# Altium schematic sheet DAC.SchDoc
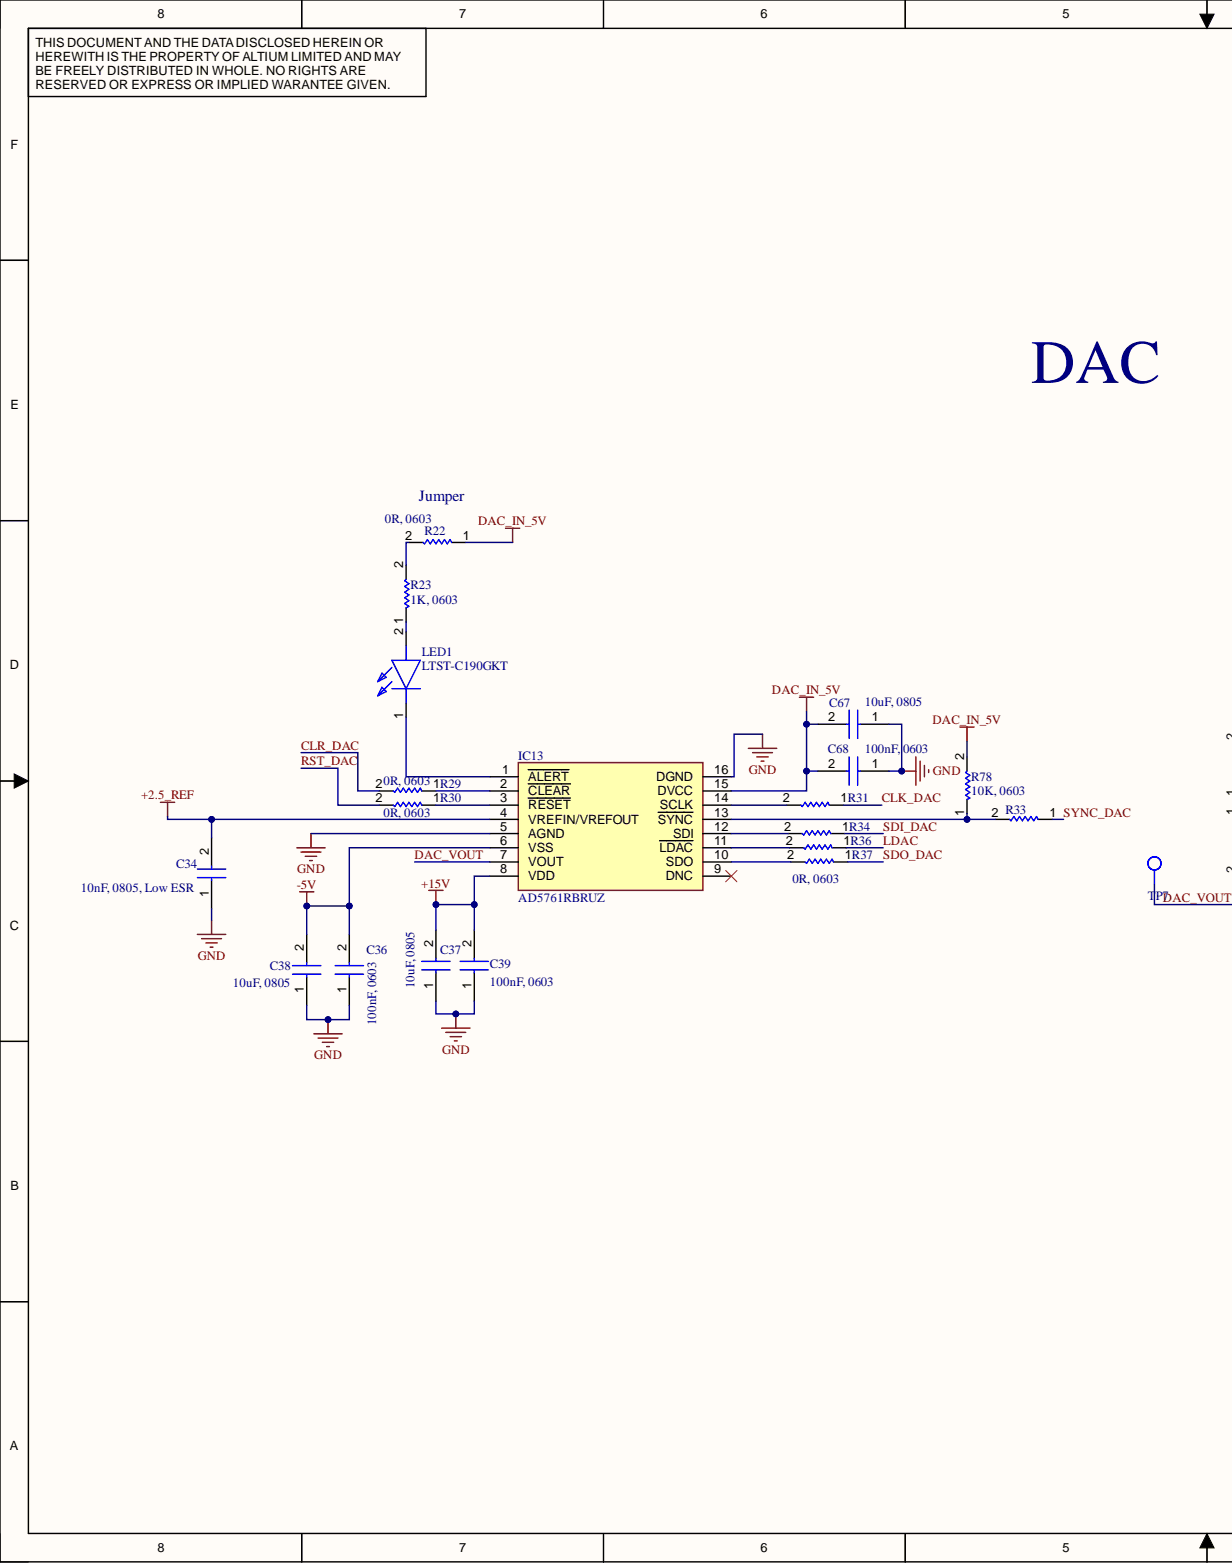
[diagram p0: DAC.SchDoc, left]
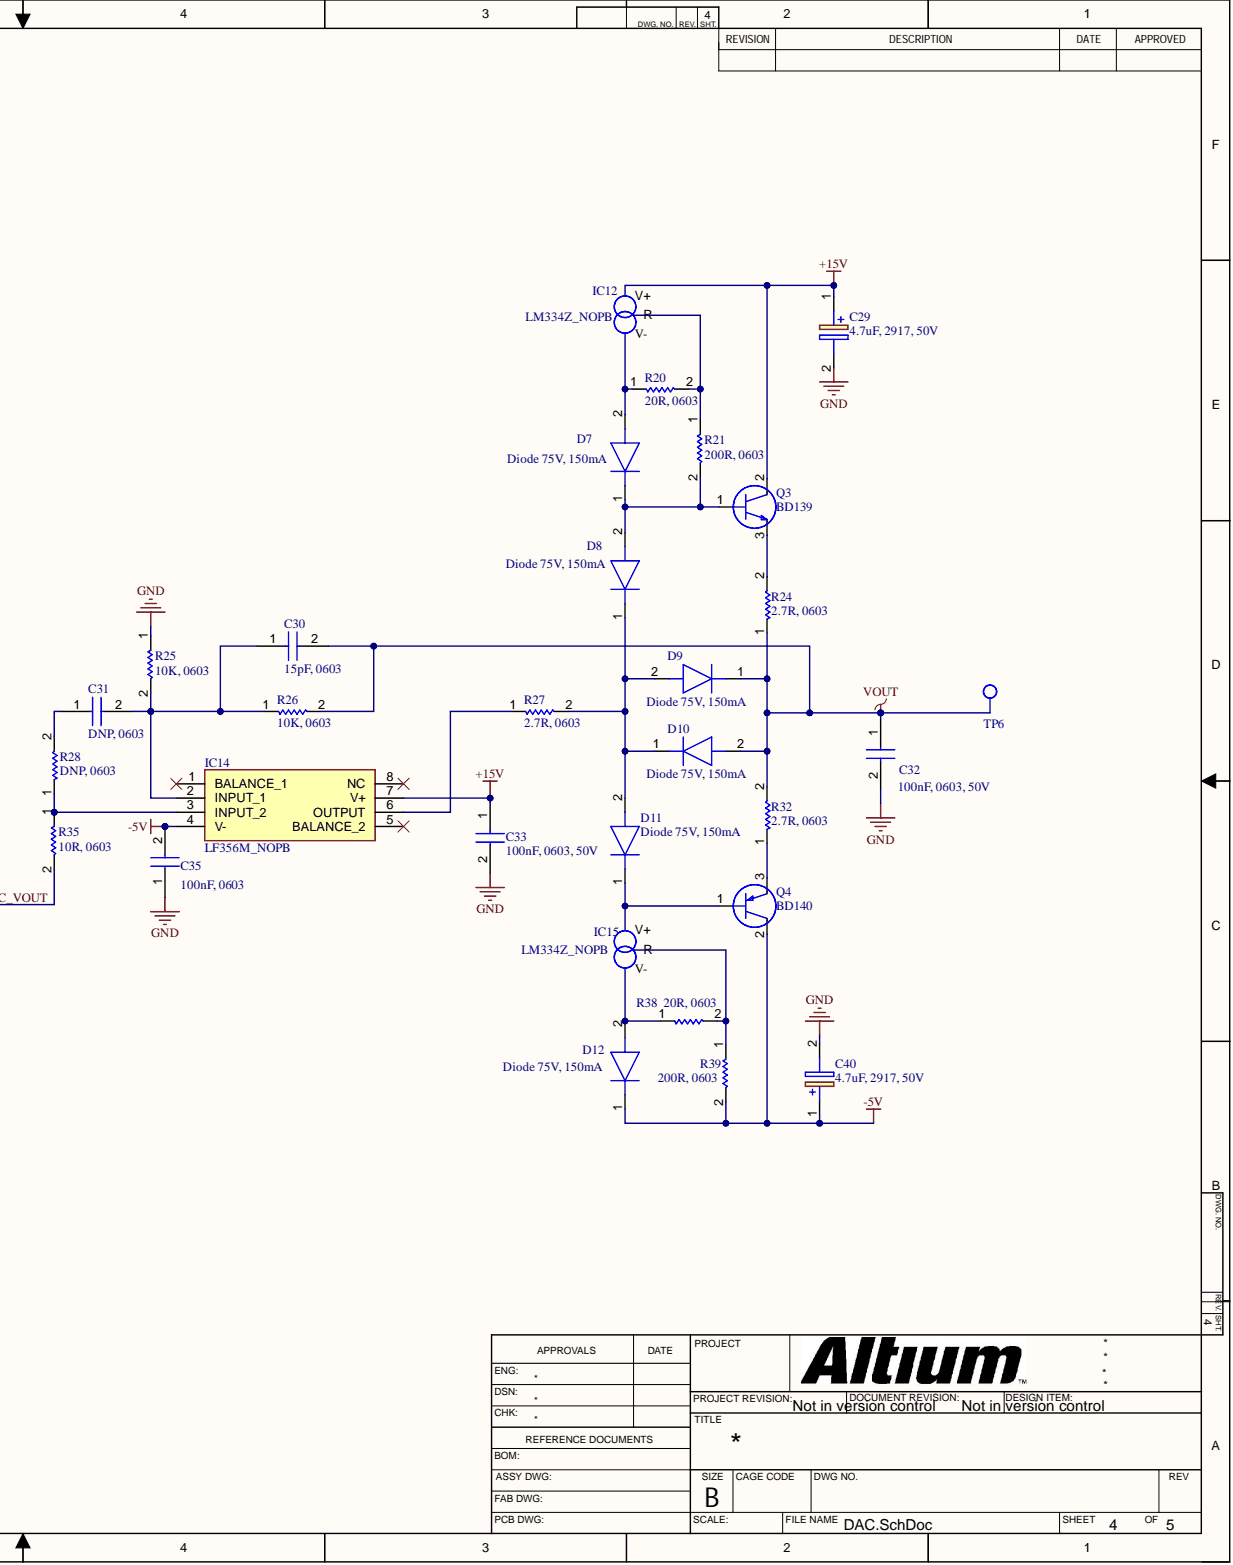
[diagram p1: DAC.SchDoc, right]

## Parts
C29  4.7uF, 2917, 50V  [CAPTANT_2917]
C30  15pF, 0603  [CAP0603]
C31  DNP, 0603  [CAP0603]
C32  100nF, 0603, 50V  [CAP0603]
C33  100nF, 0603, 50V  [CAP0603]
C34  10nF, 0805, Low ESR  [CAP0805]
C35  100nF, 0603  [CAP0603]
C36  100nF, 0603  [CAP0603]
C37  10uF, 0805  [CAP0805]
C38  10uF, 0805  [CAP0805]
C39  100nF, 0603  [CAP0603]
C40  4.7uF, 2917, 50V  [CAPTANT_2917]
C67  10uF, 0805  [CAP0805]
C68  100nF, 0603  [CAP0603]
D7  Diode 75V, 150mA  [SOD3716X135N]
D8  Diode 75V, 150mA  [SOD3716X135N]
D9  Diode 75V, 150mA  [SOD3716X135N]
D10  Diode 75V, 150mA  [SOD3716X135N]
D11  Diode 75V, 150mA  [SOD3716X135N]
D12  Diode 75V, 150mA  [SOD3716X135N]
IC12  LM334Z_NOPB  [LP(O-PBCY-W3)]
IC13  AD5761RBRUZ  [SOP65P640X120-16N]
IC14  LF356M_NOPB  [SOIC127P600X175-8N]
IC15  LM334Z_NOPB  [LP(O-PBCY-W3)]
LED1  LTST-C190GKT  [LEDC1608X90N]
Q3  BD139  [BD139]
Q4  BD140  [BD140]
R20  20R, 0603  [RES0603]
R21  200R, 0603  [RES0603]
R22  0R, 0603  [RES0603]
R23  1K, 0603  [RES0603]
R24  2.7R, 0603  [RES0603]
R25  10K, 0603  [RES0603]
R26  10K, 0603  [RES0603]
R27  2.7R, 0603  [RES0603]
R28  DNP, 0603  [RES0603]
R29  0R, 0603  [RES0603]
R30  0R, 0603  [RES0603]
R31  0R, 0603  [RES0603]  (value not drawn)
R32  2.7R, 0603  [RES0603]
R33  0R, 0603  [RES0603]  (value not drawn)
R34  0R, 0603  [RES0603]  (value not drawn)
R35  10R, 0603  [RES0603]
R36  0R, 0603  [RES0603]
R37  0R, 0603  [RES0603]
R38  20R, 0603  [RES0603]
R39  200R, 0603  [RES0603]
R78  10K, 0603  [RES0603]
TP6  Test Point  [TP_1mm]  (value not drawn)
TP7  Test Point  [TP_1mm]  (value not drawn)

## Nets
+2.5_REF: C34.2 IC13.4(VREFIN/VREFOUT)
+15V: C29.1 C33.1 C37.2 C39.2 IC12.1 IC13.8(VDD) IC14.7(V+) Q3.2
-5V: C35.2 C36.2 C38.2 C40.1 D12.1 IC13.6(VSS) IC14.4(V-) Q4.2 R39.2
DAC_IN_5V: C67.2 C68.2 IC13.15(DVCC) R22.1 R78.2
GND: C29.2 C32.2 C33.2 C34.1 C35.1 C36.1 C37.1 C38.1 C39.1 C40.2 C67.1 C68.1 IC13.5(AGND) IC13.16(DGND) R25.1
VOUT: C30.2 C32.1 D9.1 D10.2 R24.1 R26.2 R32.2 TP6.1
CLK_DAC: R31.1
CLR_DAC: R29.2
DAC_VOUT: IC13.7(VOUT) R35.2 TP7.1
LDAC: R36.1
RST_DAC: R30.2
SDI_DAC: R34.1
SDO_DAC: R37.1
SYNC_DAC: R33.1
NetC30_1: C30.1 C31.2 IC14.2(INPUT_1) R25.2 R26.1
NetC31_1: C31.1 R28.2
NetD7_1: D7.1 D8.2 Q3.1 R21.2
NetD7_2: D7.2 IC12.3 R20.1
NetD8_1: D8.1 D9.2 D10.1 D11.2 R27.2
NetD11_1: D11.1 IC15.1 Q4.1
NetD12_2: D12.2 IC15.3 R38.1
NetIC12_2: IC12.2 R20.2 R21.1
NetIC13_1: IC13.1(ALERT) LED1.1
NetIC13_2: IC13.2(CLEAR) R29.1
NetIC13_3: IC13.3(RESET) R30.1
NetIC13_10: IC13.10(SDO) R37.2
NetIC13_11: IC13.11(LDAC) R36.2
NetIC13_12: IC13.12(SDI) R34.2
NetIC13_13: IC13.13(SYNC) R33.2 R78.1
NetIC13_14: IC13.14(SCLK) R31.2
NetIC14_3: IC14.3(INPUT_2) R28.1 R35.1
NetIC14_6: IC14.6(OUTPUT) R27.1
NetIC15_2: IC15.2 R38.2 R39.1
NetLED1_2: LED1.2 R23.1
NetQ3_3: Q3.3 R24.2
NetQ4_3: Q4.3 R32.1
NetR22_2: R22.2 R23.2

Not connected: IC13 9(DNC); IC14 1(BALANCE_1) 5(BALANCE_2) 8(NC)

## Library data of the parts
C29 C40 = Tantalum Capacitor: CAP TANT 4.7UF 10% 50V 2917; Manufacturer_Name: KEMET; Manufacturer_Part_Number: T491D475K050AT; Component Kind: Standard; Library Name: Sanket.SchLib
C30 = Capacitor: CAP CER 15PF 50V C0G/NP0 0603; Manufacturer_Name: Samsung Electro-Mechanics; Manufacturer_Part_Number: CL10C150JB81PNC; Component Kind: Standard; Library Name: Sanket.SchLib
C31 = Capacitor: Component Kind: Standard; Description: Capacitor; Library Name: Sanket.SchLib
C32 C33 = Capacitor: CAP CER 0.1UF 50V X7R 0603; Manufacturer_Name: KEMET; Manufacturer_Part_Number: C0603C104K5RACTU; Component Kind: Standard; Library Name: Sanket.SchLib
C34 = Capacitor: CAP CER 0805 10NF 50V C0G 10%; Manufacturer_Name: KEMET; Manufacturer_Part_Number: C0805C103K5GEC7210; Component Kind: Standard; Library Name: Sanket.SchLib
C35 C36 C39 C68 = Capacitor: CAP CER 0.1UF 16V X7R 0603; Manufacturer_Name: YAGEO; Manufacturer_Part_Number: CC0603KRX7R7BB104; Component Kind: Standard; Library Name: Sanket.SchLib
C37 C38 C67 = Capacitor: CAP CER 10UF 16V X5R 0805; Manufacturer_Name: Samsung Electro-Mechanics; Manufacturer_Part_Number: CL21A106KOQNNNE; Component Kind: Standard; Library Name: Sanket.SchLib
D7-D12 = 1N4148W-G3-08: DIODE STANDARD 75V 150MA SOD123; Manufacturer_Name: Vishay; Component Kind: Standard; Manufacturer_Part_Number: 1N4148W-G3-08
IC12 IC15 = LM334Z_NOPB: Integrated Circuit; Manufacturer_Name: Texas Instruments; Manufacturer_Part_Number: LM334Z/NOPB; Component Kind: Standard; Library Name: Sanket.SchLib
IC13 = AD5761RBRUZ: Integrated Circuit; Manufacturer_Name: Analog Devices; Component Kind: Standard; Manufacturer_Part_Number: AD5761RBRUZ
IC14 = LF356M_NOPB: Integrated Circuit; Manufacturer_Name: Texas Instruments; Manufacturer_Part_Number: LF356M/NOPB; Component Kind: Standard
LED1 = LTST-C190GKT: LED; Manufacturer_Name: Lite-On; Component Kind: Standard; Manufacturer_Part_Number: LTST-C190GKT
Q3 = BD139: Bipolar (BJT) Transistor NPN 80 V 1.5 A 1.25 W Through Hole SOT-32-3; Manufacturer_Name: STMicroelectronics; Component Kind: Standard; Manufacturer_Part_Number: BD139; Library Name: Sanket.SchLib
Q4 = BD140: Bipolar (BJT) Transistor PNP 80 V 1.5 A 1.25 W Through Hole SOT-32-3; Manufacturer_Name: STMicroelectronics; Component Kind: Standard; Manufacturer_Part_Number: BD140; Library Name: Sanket.SchLib
R20 R38 = Resistor 0603: RES 20 OHM 5% 1/10W 0603; Manufacturer_Name: YAGEO; Manufacturer_Part_Number: RC0603JR-0720RL; Component Kind: Standard; Library Name: Sanket.SchLib
R21 R39 = Resistor 0603: RES 200 OHM 1% 1/10W 0603; Manufacturer_Name: Vishay Dale; Manufacturer_Part_Number: CRCW0603200RFKEAC; Component Kind: Standard; Library Name: Sanket.SchLib
R22 R29-R31 R33 R34 R36 R37 = Resistor 0603: RES 0 OHM JUMPER 1/10W 0603; Manufacturer_Name: Stackpole Electronics Inc; Manufacturer_Part_Number: RMCF0603ZT0R00; Component Kind: Standard; Library Name: Sanket.SchLib
R23 = Resistor 0603: RES SMD 1K OHM 0.1% 1/10W 0603; Manufacturer_Name: Panasonic Electronic Components; Manufacturer_Part_Number: ERA-3AEB102V; Component Kind: Standard; Library Name: Sanket.SchLib
R24 R27 R32 = Resistor 0603: RES SMD 2.7 OHM 5% 1/10W 0603; Manufacturer_Name: Panasonic Electronic Components; Manufacturer_Part_Number: ERJ-3GEYJ2R7V; Component Kind: Standard; Library Name: Sanket.SchLib
R25 R26 R78 = Resistor 0603: RES 10K OHM 1% 1/10W 0603; Manufacturer_Name: YAGEO; Manufacturer_Part_Number: RC0603FR-0710KL; Component Kind: Standard; Library Name: Sanket.SchLib
R28 = Resistor 0603: Component Kind: Standard; Description: Resistor 0603; Library Name: Sanket.SchLib
R35 = Resistor 0603: RES 10 OHM 1% 1/10W 0603; Manufacturer_Name: Stackpole Electronics Inc; Manufacturer_Part_Number: RMCF0603FT10R0; Component Kind: Standard; Library Name: Sanket.SchLib
TP6 TP7 = Test Point: Component Kind: Standard; Library Name: Sanket.SchLib
